# Altium schematic sheet Display Interface and SDRAM.SchDoc("Display Interface and SDRAM")
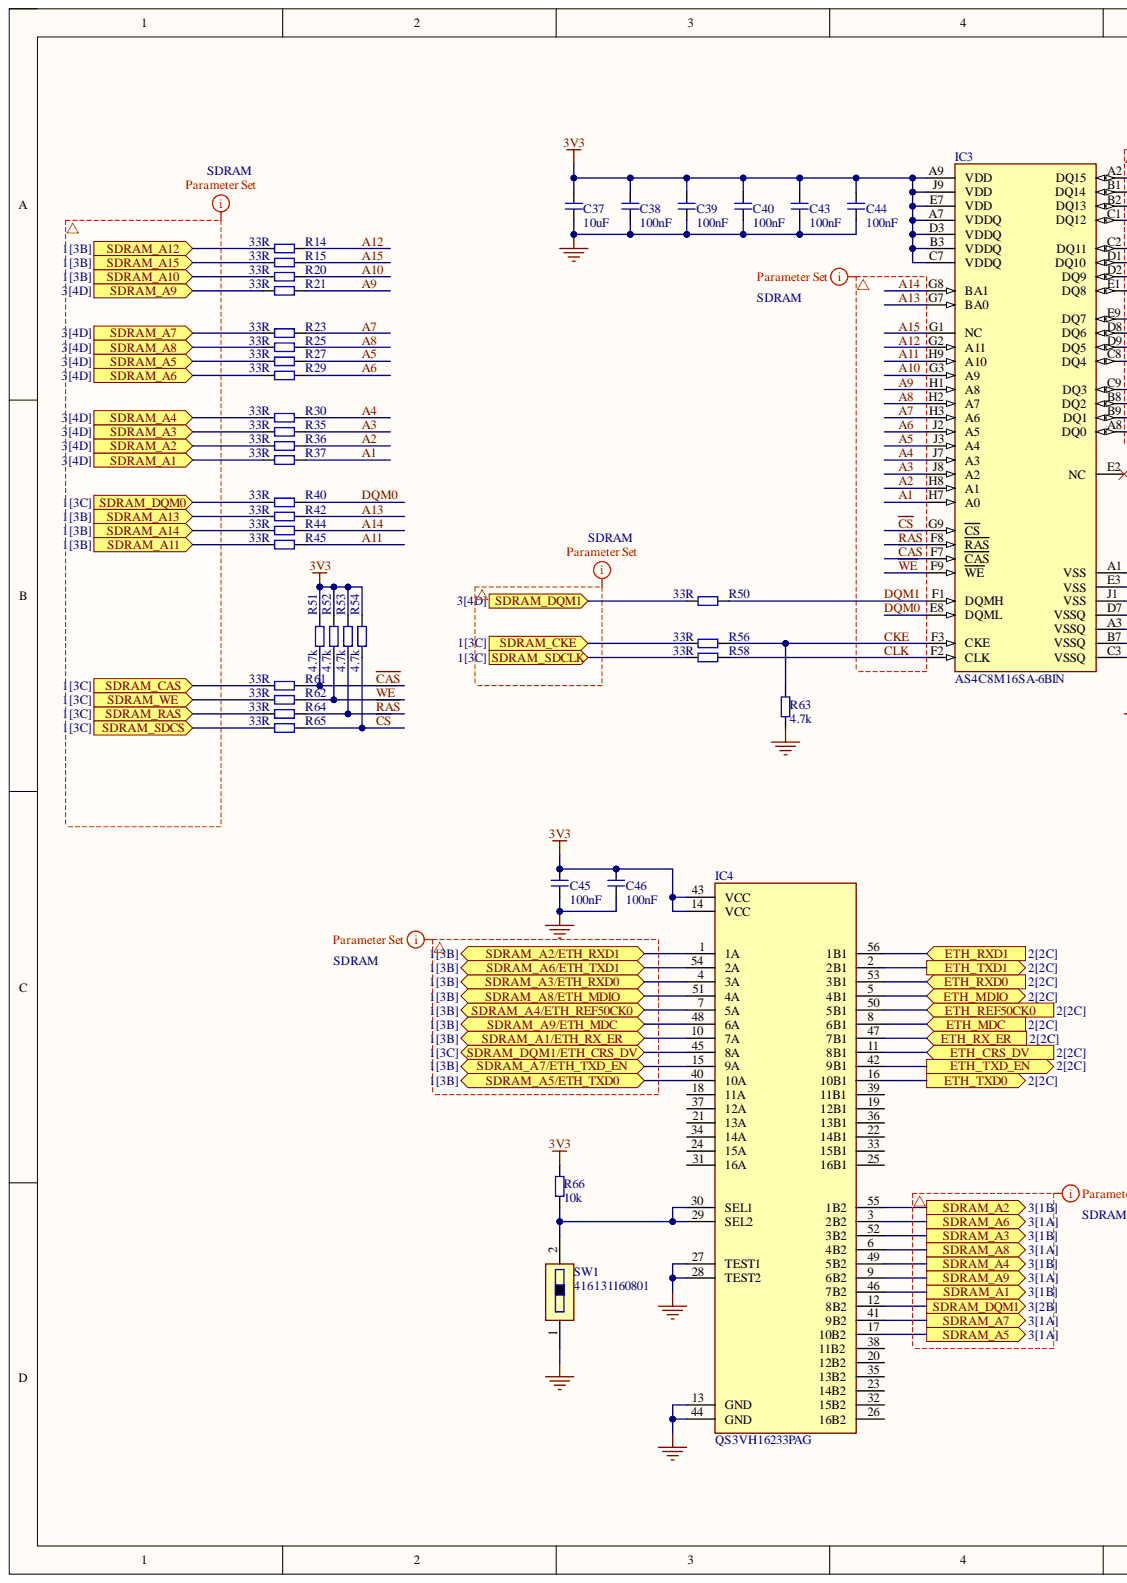
[diagram p0: Display Interface and SDRAM.SchDoc, left]
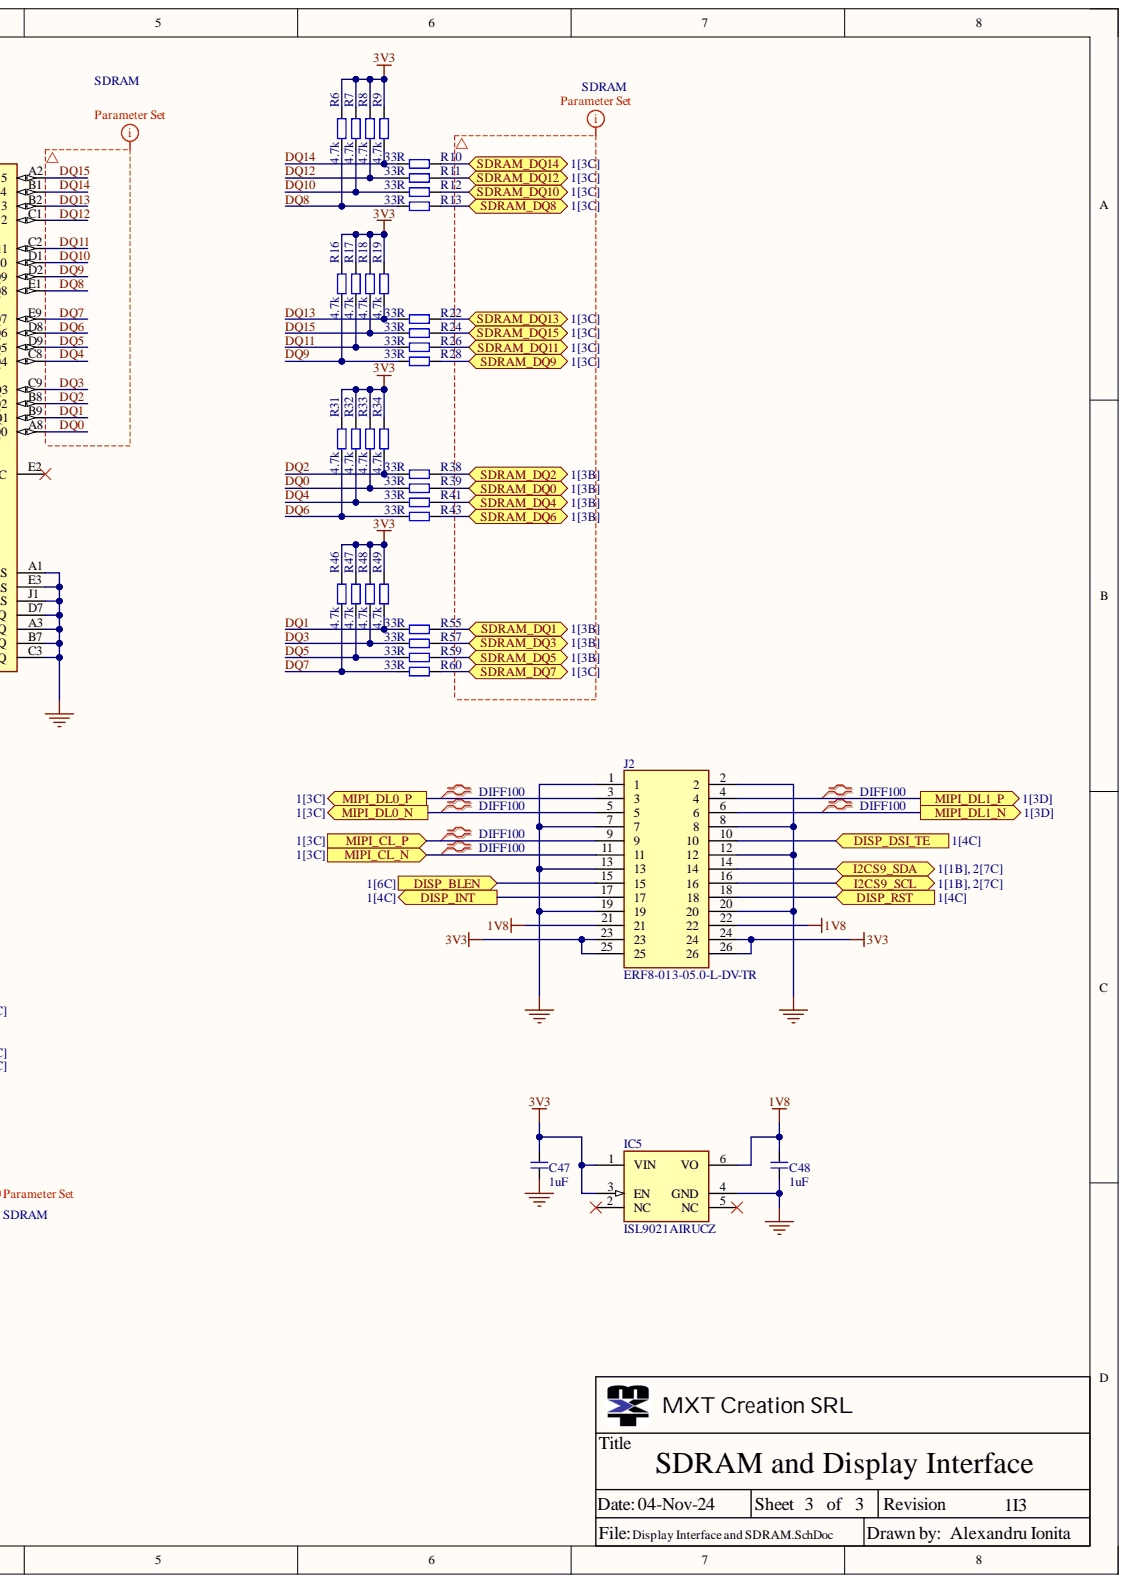
[diagram p1: Display Interface and SDRAM.SchDoc, right]

## Parts
C37  10uF  [CAP0402]
C38  100nF  [CAP0402]
C39  100nF  [CAP0402]
C40  100nF  [CAP0402]
C43  100nF  [CAP0402]
C44  100nF  [CAP0402]
C45  100nF  [CAP0402]
C46  100nF  [CAP0402]
C47  1uF  [CAP0402]
C48  1uF  [CAP0402]
IC3  AS4C8M16SA-6BIN  [54-TFBGA-Alliance]
IC4  QS3VH16233PAG  [56-TSSOP-RENESAS]
IC5  ISL9021AIRUCZ  [6-UDFN-RENESAS]
J2  ERF8-013-05.0-L-DV-TR  [ERF8-013-05.0-L-DV-TR]
R6  4.7k  [RES0402]
R7  4.7k  [RES0402]
R8  4.7k  [RES0402]
R9  4.7k  [RES0402]
R10  33R  [RES0402]
R11  33R  [RES0402]
R12  33R  [RES0402]
R13  33R  [RES0402]
R14  33R  [RES0402]
R15  33R  [RES0402]
R16  4.7k  [RES0402]
R17  4.7k  [RES0402]
R18  4.7k  [RES0402]
R19  4.7k  [RES0402]
R20  33R  [RES0402]
R21  33R  [RES0402]
R22  33R  [RES0402]
R23  33R  [RES0402]
R24  33R  [RES0402]
R25  33R  [RES0402]
R26  33R  [RES0402]
R27  33R  [RES0402]
R28  33R  [RES0402]
R29  33R  [RES0402]
R30  33R  [RES0402]
R31  4.7k  [RES0402]
R32  4.7k  [RES0402]
R33  4.7k  [RES0402]
R34  4.7k  [RES0402]
R35  33R  [RES0402]
R36  33R  [RES0402]
R37  33R  [RES0402]
R38  33R  [RES0402]
R39  33R  [RES0402]
R40  33R  [RES0402]
R41  33R  [RES0402]
R42  33R  [RES0402]
R43  33R  [RES0402]
R44  33R  [RES0402]
R45  33R  [RES0402]
R46  4.7k  [RES0402]
R47  4.7k  [RES0402]
R48  4.7k  [RES0402]
R49  4.7k  [RES0402]
R50  33R  [RES0402]
R51  4.7k  [RES0402]
R52  4.7k  [RES0402]
R53  4.7k  [RES0402]
R54  4.7k  [RES0402]
R55  33R  [RES0402]
R56  33R  [RES0402]
R57  33R  [RES0402]
R58  33R  [RES0402]
R59  33R  [RES0402]
R60  33R  [RES0402]
R61  33R  [RES0402]
R62  33R  [RES0402]
R63  4.7k  [RES0402]
R64  33R  [RES0402]
R65  33R  [RES0402]
R66  10k  [RES0402]
SW1  416131160801  [WE-1-DIP]

## Ports
DISP_BLEN, DISP_DSI_TE, DISP_INT, DISP_RST, ETH_CRS_DV, ETH_MDC, ETH_MDIO, ETH_REF50CK0, ETH_RX_ER, ETH_RXD0, ETH_RXD1, ETH_TXD0, ETH_TXD1, ETH_TXD_EN, I2CS9_SCL, I2CS9_SDA, MIPI_CL_N, MIPI_CL_P, MIPI_DL0_N, MIPI_DL0_P, MIPI_DL1_N, MIPI_DL1_P, SDRAM_A1, SDRAM_A1/ETH_RX_ER, SDRAM_A2, SDRAM_A2/ETH_RXD1, SDRAM_A3, SDRAM_A3/ETH_RXD0, SDRAM_A4, SDRAM_A4/ETH_REF50CK0, SDRAM_A5, SDRAM_A5/ETH_TXD0, SDRAM_A6, SDRAM_A6/ETH_TXD1, SDRAM_A7, SDRAM_A7/ETH_TXD_EN, SDRAM_A8, SDRAM_A8/ETH_MDIO, SDRAM_A9, SDRAM_A9/ETH_MDC, SDRAM_A10, SDRAM_A11, SDRAM_A12, SDRAM_A13, SDRAM_A14, SDRAM_A15, SDRAM_CAS, SDRAM_CKE, SDRAM_DQ0, SDRAM_DQ1, SDRAM_DQ2, SDRAM_DQ3, SDRAM_DQ4, SDRAM_DQ5, SDRAM_DQ6, SDRAM_DQ7, SDRAM_DQ8, SDRAM_DQ9, SDRAM_DQ10, SDRAM_DQ11, SDRAM_DQ12, SDRAM_DQ13, SDRAM_DQ14, SDRAM_DQ15, SDRAM_DQM0, SDRAM_DQM1, SDRAM_DQM1/ETH_CRS_DV, SDRAM_RAS, SDRAM_SDCLK, SDRAM_SDCS, SDRAM_WE

## Nets
1V8: C48.2 IC5.6(VO) J2.21 J2.22
3V3: C37.2 C38.2 C39.2 C40.2 C43.2 C44.2 C45.2 C46.2 C47.2 IC3.A7(VDDQ) IC3.A9(VDD) IC3.B3(VDDQ) IC3.C7(VDDQ) IC3.D3(VDDQ) IC3.E7(VDD) IC3.J9(VDD) IC4.14(VCC) IC4.43(VCC) IC5.1(VIN) IC5.3(EN) J2.23 J2.24 J2.25 J2.26 R6.2 R7.2 R8.2 R9.2 R16.2 R17.2 R18.2 R19.2 R31.2 R32.2 R33.2 R34.2 R46.2 R47.2 R48.2 R49.2 R51.2 R52.2 R53.2 R54.2 R66.2
GND: C37.1 C38.1 C39.1 C40.1 C43.1 C44.1 C45.1 C46.1 C47.1 C48.1 IC3.A1(VSS) IC3.A3(VSSQ) IC3.B7(VSSQ) IC3.C3(VSSQ) IC3.D7(VSSQ) IC3.E3(VSS) IC3.J1(VSS) IC4.13(GND) IC4.27(TEST1) IC4.28(TEST2) IC4.44(GND) IC5.4(GND) J2.1 J2.2 J2.7 J2.8 J2.12 J2.13 J2.19 J2.20 R63.1 SW1.1
A1: IC3.H7(A0) R37.2
A2: IC3.H8(A1) R36.2
A3: IC3.J8(A2) R35.2
A4: IC3.J7(A3) R30.2
A5: IC3.J3(A4) R27.2
A6: IC3.J2(A5) R29.2
A7: IC3.H3(A6) R23.2
A8: IC3.H2(A7) R25.2
A9: IC3.H1(A8) R21.2
A10: IC3.G3(A9) R20.2
A11: IC3.H9(A10) R45.2
A12: IC3.G2(A11) R14.2
A13: IC3.G7(BA0) R42.2
A14: IC3.G8(BA1) R44.2
A15: IC3.G1(NC) R15.2
~{CAS}: IC3.F7(CAS) R51.1 R61.2
~{CS}: IC3.G9(CS) R54.1 R65.2
CKE: IC3.F3(CKE) R56.2 R63.2
CLK: IC3.F2(CLK) R58.2
DISP_BLEN: J2.15 ; port DISP_BLEN
DISP_DSI_TE: J2.10 ; port DISP_DSI_TE
DISP_INT: J2.17 ; port DISP_INT
DISP_RST: J2.18 ; port DISP_RST
DQ0: IC3.A8(DQ0) R33.1 R39.1
DQ1: IC3.B9(DQ1) R49.1 R55.1
DQ2: IC3.B8(DQ2) R34.1 R38.1
DQ3: IC3.C9(DQ3) R48.1 R57.1
DQ4: IC3.C8(DQ4) R32.1 R41.1
DQ5: IC3.D9(DQ5) R47.1 R59.1
DQ6: IC3.D8(DQ6) R31.1 R43.1
DQ7: IC3.E9(DQ7) R46.1 R60.1
DQ8: IC3.E1(DQ8) R6.1 R13.1
DQ9: IC3.D2(DQ9) R16.1 R28.1
DQ10: IC3.D1(DQ10) R7.1 R12.1
DQ11: IC3.C2(DQ11) R17.1 R26.1
DQ12: IC3.C1(DQ12) R8.1 R11.1
DQ13: IC3.B2(DQ13) R19.1 R22.1
DQ14: IC3.B1(DQ14) R9.1 R10.1
DQ15: IC3.A2(DQ15) R18.1 R24.1
DQM0: IC3.E8(DQML) R40.2
DQM1: IC3.F1(DQMH) R50.2
ETH_CRS_DV: IC4.11(8B1) ; port ETH_CRS_DV
ETH_MDC: IC4.8(6B1) ; port ETH_MDC
ETH_MDIO: IC4.5(4B1) ; port ETH_MDIO
ETH_REF50CK0: IC4.50(5B1) ; port ETH_REF50CK0
ETH_RX_ER: IC4.47(7B1) ; port ETH_RX_ER
ETH_RXD0: IC4.53(3B1) ; port ETH_RXD0
ETH_RXD1: IC4.56(1B1) ; port ETH_RXD1
ETH_TXD0: IC4.16(10B1) ; port ETH_TXD0
ETH_TXD1: IC4.2(2B1) ; port ETH_TXD1
ETH_TXD_EN: IC4.42(9B1) ; port ETH_TXD_EN
I2CS9_SCL: J2.16 ; port I2CS9_SCL
I2CS9_SDA: J2.14 ; port I2CS9_SDA
MIPI_CL_N: J2.11 ; port MIPI_CL_N
MIPI_CL_P: J2.9 ; port MIPI_CL_P
MIPI_DL0_N: J2.5 ; port MIPI_DL0_N
MIPI_DL0_P: J2.3 ; port MIPI_DL0_P
MIPI_DL1_N: J2.6 ; port MIPI_DL1_N
MIPI_DL1_P: J2.4 ; port MIPI_DL1_P
~{RAS}: IC3.F8(RAS) R53.1 R64.2
SDRAM_A1: IC4.46(7B2) R37.1 ; port SDRAM_A1
SDRAM_A1/ETH_RX_ER: IC4.10(7A) ; port SDRAM_A1/ETH_RX_ER
SDRAM_A2: IC4.55(1B2) R36.1 ; port SDRAM_A2
SDRAM_A2/ETH_RXD1: IC4.1(1A) ; port SDRAM_A2/ETH_RXD1
SDRAM_A3: IC4.52(3B2) R35.1 ; port SDRAM_A3
SDRAM_A3/ETH_RXD0: IC4.4(3A) ; port SDRAM_A3/ETH_RXD0
SDRAM_A4: IC4.49(5B2) R30.1 ; port SDRAM_A4
SDRAM_A4/ETH_REF50CK0: IC4.7(5A) ; port SDRAM_A4/ETH_REF50CK0
SDRAM_A5: IC4.17(10B2) R27.1 ; port SDRAM_A5
SDRAM_A5/ETH_TXD0: IC4.40(10A) ; port SDRAM_A5/ETH_TXD0
SDRAM_A6: IC4.3(2B2) R29.1 ; port SDRAM_A6
SDRAM_A6/ETH_TXD1: IC4.54(2A) ; port SDRAM_A6/ETH_TXD1
SDRAM_A7: IC4.41(9B2) R23.1 ; port SDRAM_A7
SDRAM_A7/ETH_TXD_EN: IC4.15(9A) ; port SDRAM_A7/ETH_TXD_EN
SDRAM_A8: IC4.6(4B2) R25.1 ; port SDRAM_A8
SDRAM_A8/ETH_MDIO: IC4.51(4A) ; port SDRAM_A8/ETH_MDIO
SDRAM_A9: IC4.9(6B2) R21.1 ; port SDRAM_A9
SDRAM_A9/ETH_MDC: IC4.48(6A) ; port SDRAM_A9/ETH_MDC
SDRAM_A10: R20.1 ; port SDRAM_A10
SDRAM_A11: R45.1 ; port SDRAM_A11
SDRAM_A12: R14.1 ; port SDRAM_A12
SDRAM_A13: R42.1 ; port SDRAM_A13
SDRAM_A14: R44.1 ; port SDRAM_A14
SDRAM_A15: R15.1 ; port SDRAM_A15
SDRAM_CAS: R61.1 ; port SDRAM_CAS
SDRAM_CKE: R56.1 ; port SDRAM_CKE
SDRAM_DQ0: R39.2 ; port SDRAM_DQ0
SDRAM_DQ1: R55.2 ; port SDRAM_DQ1
SDRAM_DQ2: R38.2 ; port SDRAM_DQ2
SDRAM_DQ3: R57.2 ; port SDRAM_DQ3
SDRAM_DQ4: R41.2 ; port SDRAM_DQ4
SDRAM_DQ5: R59.2 ; port SDRAM_DQ5
SDRAM_DQ6: R43.2 ; port SDRAM_DQ6
SDRAM_DQ7: R60.2 ; port SDRAM_DQ7
SDRAM_DQ8: R13.2 ; port SDRAM_DQ8
SDRAM_DQ9: R28.2 ; port SDRAM_DQ9
SDRAM_DQ10: R12.2 ; port SDRAM_DQ10
SDRAM_DQ11: R26.2 ; port SDRAM_DQ11
SDRAM_DQ12: R11.2 ; port SDRAM_DQ12
SDRAM_DQ13: R22.2 ; port SDRAM_DQ13
SDRAM_DQ14: R10.2 ; port SDRAM_DQ14
SDRAM_DQ15: R24.2 ; port SDRAM_DQ15
SDRAM_DQM0: R40.1 ; port SDRAM_DQM0
SDRAM_DQM1: IC4.12(8B2) R50.1 ; port SDRAM_DQM1
SDRAM_DQM1/ETH_CRS_DV: IC4.45(8A) ; port SDRAM_DQM1/ETH_CRS_DV
SDRAM_RAS: R64.1 ; port SDRAM_RAS
SDRAM_SDCLK: R58.1 ; port SDRAM_SDCLK
SDRAM_SDCS: R65.1 ; port SDRAM_SDCS
SDRAM_WE: R62.1 ; port SDRAM_WE
~{WE}: IC3.F9(WE) R52.1 R62.2
NetIC4_29: IC4.29(SEL2) IC4.30(SEL1) R66.1 SW1.2

Not connected: IC3 E2(NC); IC4 18(11A) 19(12B1) 20(12B2) 21(13A) 22(14B1) 23(14B2) 24(15A) 25(16B1) 26(16B2) 31(16A) 32(15B2) 33(15B1) 34(14A) 35(13B2) 36(13B1) 37(12A) 38(11B2) 39(11B1); IC5 2(NC) 5(NC)

## Library data of the parts
C37 = GRM155R60J106ME47D: CAP CER 10UF 20% 6.3V X5R 0402; Manufacturer: Murata; .Checked_PCB: AI; Component Kind: Standard; Manufacturer Part Number: GRM155R60J106ME47D; .Checked_SCH: AI; .Creator: AI; Library Name: MXT_Library.IntLib
C38-C40 C43-C46 = GRM155R71E104KE14J: CAP CER 0.1UF 10% 25V X7R 0402; Manufacturer: Murata; .Checked_PCB: AI; Component Kind: Standard; Manufacturer Part Number: GRM155R71E104KE14J; .Checked_SCH: AI; .Creator: AI; Library Name: MXT_Library.IntLib
C47 C48 = GRM155R61E105KA12J: CAP CER 1UF 10% 25V X5R 0402; Manufacturer: Murata; .Checked_PCB: AI; Component Kind: Standard; Manufacturer Part Number: GRM155R61E105KA12J; .Checked_SCH: AI; .Creator: AI; Library Name: MXT_Library.IntLib
IC3 = AS4C8M16SA-6BIN: Alliance Memory SDRAM 128M-Bit 8Mx16 3.3V TF-BGA 8x8; .Creator: AI; Component Kind: Standard; Manufacturer: Alliance Memory; Manufacturer Part Number: AS4C8M16SA-6BIN; Library Name: MXT_Library.IntLib
IC4 = QS3VH16233PAG: 3.3V 32:16 MUX/DEMUX  HIGH BANDWIDTH BUS SWITCH; Manufacturer: Renesas; .Creator: AI; Component Kind: Standard; Manufacturer Part Number: QS3VH16233PAG; Library Name: MXT_Library.IntLib
IC5 = ISL9021AIRUCZ: 1.8V 250mA LDO; Manufacturer: Renesas; .Creator: AI; Component Kind: Standard; Manufacturer Part Number: ISL9021AIRUCZ; Library Name: MXT_Library.IntLib
J2 = ERF8-013-05.0-L-DV-TR: Board to Board & Mezzanine Connectors 0.80 mm Edge Rate Rugged High Speed Socket; Manufacturer: Samtec; .Creator: AI; Component Kind: Standard; Manufacturer Part Number: ERF8-013-05.0-L-DV-TR; Library Name: MXT_Library.IntLib
R6-R9 R16-R19 R31-R34 R46-R49 R51-R54 R63 = RC0402FR-074K7L: RES SMD 4.7K OHM 1% 1/16W 0402; Manufacturer: Yageo; .Checked_PCB: AI; Component Kind: Standard; Manufacturer Part Number: RC0402FR-074K7L; .Checked_SCH: AI; .Creator: AI; Library Name: MXT_Library.IntLib
R10-R15 R20-R30 R35-R45 R50 R55-R62 R64 R65 = RC0402FR-0733RL: RES SMD 33 OHM 1% 1/16W 0402; Manufacturer: Yageo; Component Kind: Standard; Manufacturer Part Number: RC0402FR-0733RL; Library Name: MXT_Library.IntLib
R66 = RC0402FR-0710KL: RES SMD 10K OHM 1% 1/16W 0402; Manufacturer: Yageo; .Checked_PCB: AI; Component Kind: Standard; Manufacturer Part Number: RC0402FR-0710KL; .Checked_SCH: AI; .Creator: AI; Library Name: MXT_Library.IntLib
SW1 = 416131160801: 1-pin low profile dip-switch 1.27mm pitch; Manufacturer: Wurth Elektronik; Component Kind: Standard; Manufacturer Part Number: 416131160801; Library Name: MXT_Library.IntLib
